# Altium schematic sheet relay_module.SchDoc
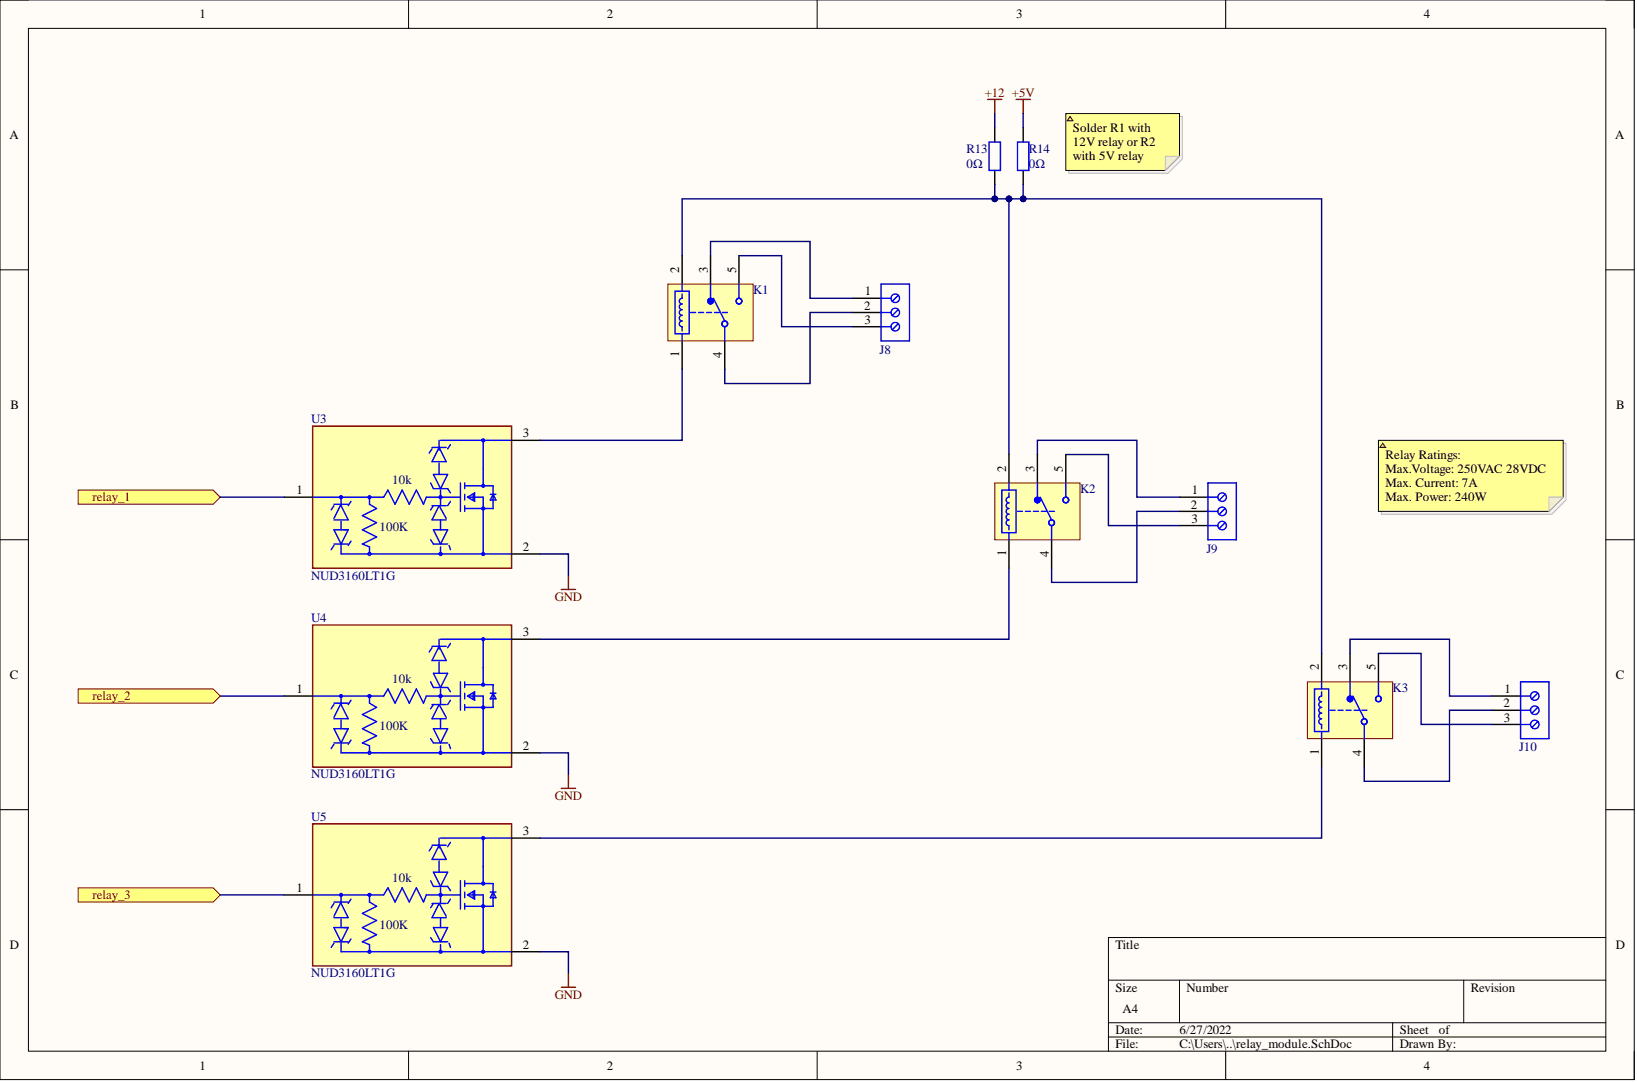
[diagram p0: relay_module.SchDoc]

## Parts
J8  282836-3  [TE_282836-3]  (value not drawn)
J9  282836-3  [TE_282836-3]  (value not drawn)
J10  282836-3  [TE_282836-3]  (value not drawn)
K1  RELAY_SRD-05VDC-SL-C  [SRD-05-VDC-SL-C]  (value not drawn)
K2  RELAY_SRD-05VDC-SL-C  [SRD-05-VDC-SL-C]  (value not drawn)
K3  RELAY_SRD-05VDC-SL-C  [SRD-05-VDC-SL-C]  (value not drawn)
R13  0Ω  [RESC2013X50X30ML20T20]
R14  0Ω  [RESC2013X50X30ML20T20]
U3  NUD3160LT1G  [FP-318-08-MFG]
U4  NUD3160LT1G  [FP-318-08-MFG]
U5  NUD3160LT1G  [FP-318-08-MFG]

## Ports
relay_1, relay_2, relay_3

## Nets
+5V: R14.1
+12: R13.1
GND: U3.2 U4.2 U5.2
relay_1: U3.1 ; port relay_1
relay_2: U4.1 ; port relay_2
relay_3: U5.1 ; port relay_3
NetJ8_1: J8.1 K1.3
NetJ8_2: J8.2 K1.4
NetJ8_3: J8.3 K1.5
NetJ9_1: J9.1 K2.3
NetJ9_2: J9.2 K2.4
NetJ9_3: J9.3 K2.5
NetJ10_1: J10.1 K3.3
NetJ10_2: J10.2 K3.4
NetJ10_3: J10.3 K3.5
NetK1_1: K1.1 U3.3
NetK1_2: K1.2 K2.2 K3.2 R13.2 R14.2
NetK2_1: K2.1 U4.3
NetK3_1: K3.1 U5.3

## Library data of the parts
J8-J10 = 282836-3: Connector, Terminal Block; Wire Receptacle; 3 Pos.; 16-30 AWG; 0.197 in. CL; Sid; Manufacturer: TE Connectivity; Approvals: UL; Closed End: No (Without); Current: 13.5A; Interlock: Yes (With); Max Supply Voltage (AC): 300V; Number of Circuits: 3; Number of Levels: 1; Pitch: 5mm; Plating: Brass; Tail Length: 3.5mm; Thread Size: M3; Voltage Rating (AC): 250V; Wire Gauge (Max): 30AWG; Wire Gauge (Min): 16AWG; Category: Terminal Blocks; Color: Green; Component Kind: Standard; ComponentLink1Description: https://datasheet.ciiva.com/15169/getdatasheetpartid-155856-15169554.pdf?src-supplier=Verical; ComponentLink1URL: https://datasheet.ciiva.com/15169/getdatasheetpartid-155856-15169554.pdf?src-supplier=Verical; ComponentLink2Description: https://datasheet.ciiva.com/16305/eng-cs-1308389-eurostyle-terminal-blocks-0607-16305242.pdf?src-supplier=Future+Electronics; ComponentLink2URL: https://datasheet.ciiva.com/16305/eng-cs-1308389-eurostyle-terminal-blocks-0607-16305242.pdf?src-supplier=Future+Electronics; ComponentLink3Description: https://datasheet.ciiva.com/19460/750661-19460522.pdf?src-supplier=Jameco; ComponentLink3URL: https://datasheet.ciiva.com/19460/750661-19460522.pdf?src-supplier=Jameco; ComponentLink4Description: https://datasheet.ciiva.com/11757/0900766b8002dc8a-11757406.pdf?src-supplier=RSComponents; ComponentLink4URL: https://datasheet.ciiva.com/11757/0900766b8002dc8a-11757406.pdf?src-supplier=RSComponents; ComponentLink5Description: https://datasheet.ciiva.com/823/eng-cd-282836-h10-823445.pdf?src-supplier=Digi-Key; ComponentLink5URL: https://datasheet.ciiva.com/823/eng-cd-282836-h10-823445.pdf?src-supplier=Digi-Key; ComponentLink6Description: https://datasheet.ciiva.com/30106/eng-cs-1308389-eurostyle-terminal-blocks-0607-30106198.pdf?src-supplier=Onlinecomponents.com; ComponentLink6URL: https://datasheet.ciiva.com/30106/eng-cs-1308389-eurostyle-terminal-blocks-0607-30106198.pdf?src-supplier=Onlinecomponents.com; ComponentLink7Description: https://datasheet.ciiva.com/14606/70086276-14606907.pdf?src-supplier=Allied+Electronics; ComponentLink7URL: https://datasheet.ciiva.com/14606/70086276-14606907.pdf?src-supplier=Allied+Electronics; ComponentLink8Description: https://datasheet.ciiva.com/16649/eng-cs-1308389-eurostyle-terminal-blocks-0607-16649389.pdf?src-supplier=Avnet+Europe; ComponentLink8URL: https://datasheet.ciiva.com/16649/eng-cs-1308389-eurostyle-terminal-blocks-0607-16649389.pdf?src-supplier=Avnet+Europe; ComponentLink9Description: https://datasheet.ciiva.com/3141/eng-cs-1308389-eurostyle-terminal-blocks-0607-3141119.pdf?src-supplier=Avnet; ComponentLink9URL: https://datasheet.ciiva.com/3141/eng-cs-1308389-eurostyle-terminal-blocks-0607-3141119.pdf?src-supplier=Avnet; Connector Type: Receptacle; Contact Material: Brass; Contact Plating: Tin; Current Rating: 13.5A; Depth: 8.2mm; ELV: Compliant; Flammability Rating: UL94 V-0; Housing Color: Green; Housing Material: Polyamide; Insulation Material: Nylon; Lead Free: Lead Free; Length: 15mm; Library Name: Schlib.SchLib; Manufacturer Part Number: 282836-3; Max Current Rating: 13.5A; Max Voltage Rating (AC): 300V; Mount: Through Hole,Board; Number of Contacts: 3; Number of Poles: 3; Number of Positions: 3; Number of Positions Per Level: 3; Number of Rows: 1; Orientation: Straight; Package Quantity: 200; Packaging: Bulk; Pricing 1: 1=0.96000, 10=0.57500, 50=0.57500, 100=0.48300, 600=0.45700, 1000=0.40600, 2600=0.36800, 4800=0.33000, 10000=0.33000, 11200=0.31700, 25600=0.31100 (USD); Radiation Hardening: No; REACH SVHC: Unknown; RMS Current (Irms): 13.5A; RoHS: Yes; RoHS Compliant: Yes; Screw Flange: No (Without); Screwless Terminal Block: No (Without); Series: Buchanan; Side Stackable: Yes (With); Stock 1: 394; Supplier 1: Mouser; Supplier Part Number 1: 571-2828363; Termination: Screw; Voltage: 300V; Voltage Rating: 300V; Width: 10mm; Wire/Cable Gauge: 16AWG; Working Voltage: 250V
K1-K3 = RELAY_SRD-05VDC-SL-C: 5VDC SPDT Relay; ca: 7A; Max. AC current: 3A; Switching power: 240W; Component Kind: Standard; Library Name: integrated_library.IntLib; Max. DC current: 7A
R13 R14 = Res_0805: Resistor; Component Kind: Standard; Library Name: PCB_Project.SchLib; Signal Integrity: Res; Simulation: RESISTOR
U3-U5 = CMP-07123-000002-1: IC INDCT LOAD DRVR INDUST SOT23; Manufacturer: ON Semiconductor; Fall Time: 622ns; Max Junction Temp: +150°C; Max Power Dissipation: 225mW; Max Supply Voltage: 60V; Number Of Drivers: 1; Output Current: 150mA; Output Voltage: 48V; Propagation Delay: 1160ns; Rise Time: 600ns; Standoff Height: 0.01mm; Ambient Temperature Range High: +125°C; Ambient Temperature Range Low: -40°C; Automotive: No; Category: IC; Component Kind: Standard; ComponentLink2Description: Datasheet; ComponentLink2URL: https://www.onsemi.com/pub/Collateral/NUD3160-D.PDF; Device Class L1: Integrated Circuits (ICs); Device Class L2: Power Management ICs; Device Class L3: Gate Drivers; Digikey Description: IC INDCT LOAD DRVR INDUST SOT23; Footprint Url: https://www.onsemi.com/pub/Collateral/318-08.PDF; Height: 1.11mm; Lead Free: Yes; Library Name: Altium Content Vault; Manufacturer Part Number: NUD3160LT1G; Mouser Description: MOSFET 61V Industrial Load Driver; Number Of Outputs: 1; Octopart: https://octopart.com/nud3160lt1g-on+semiconductor-470723; Package: 318-08; RoHS: TRUE; Temperature: -40°C to +150°C; Temperature Range High: +150°C; Temperature Range Low: -40°C
